# Altium schematic sheet 01.W7500.SchDoc
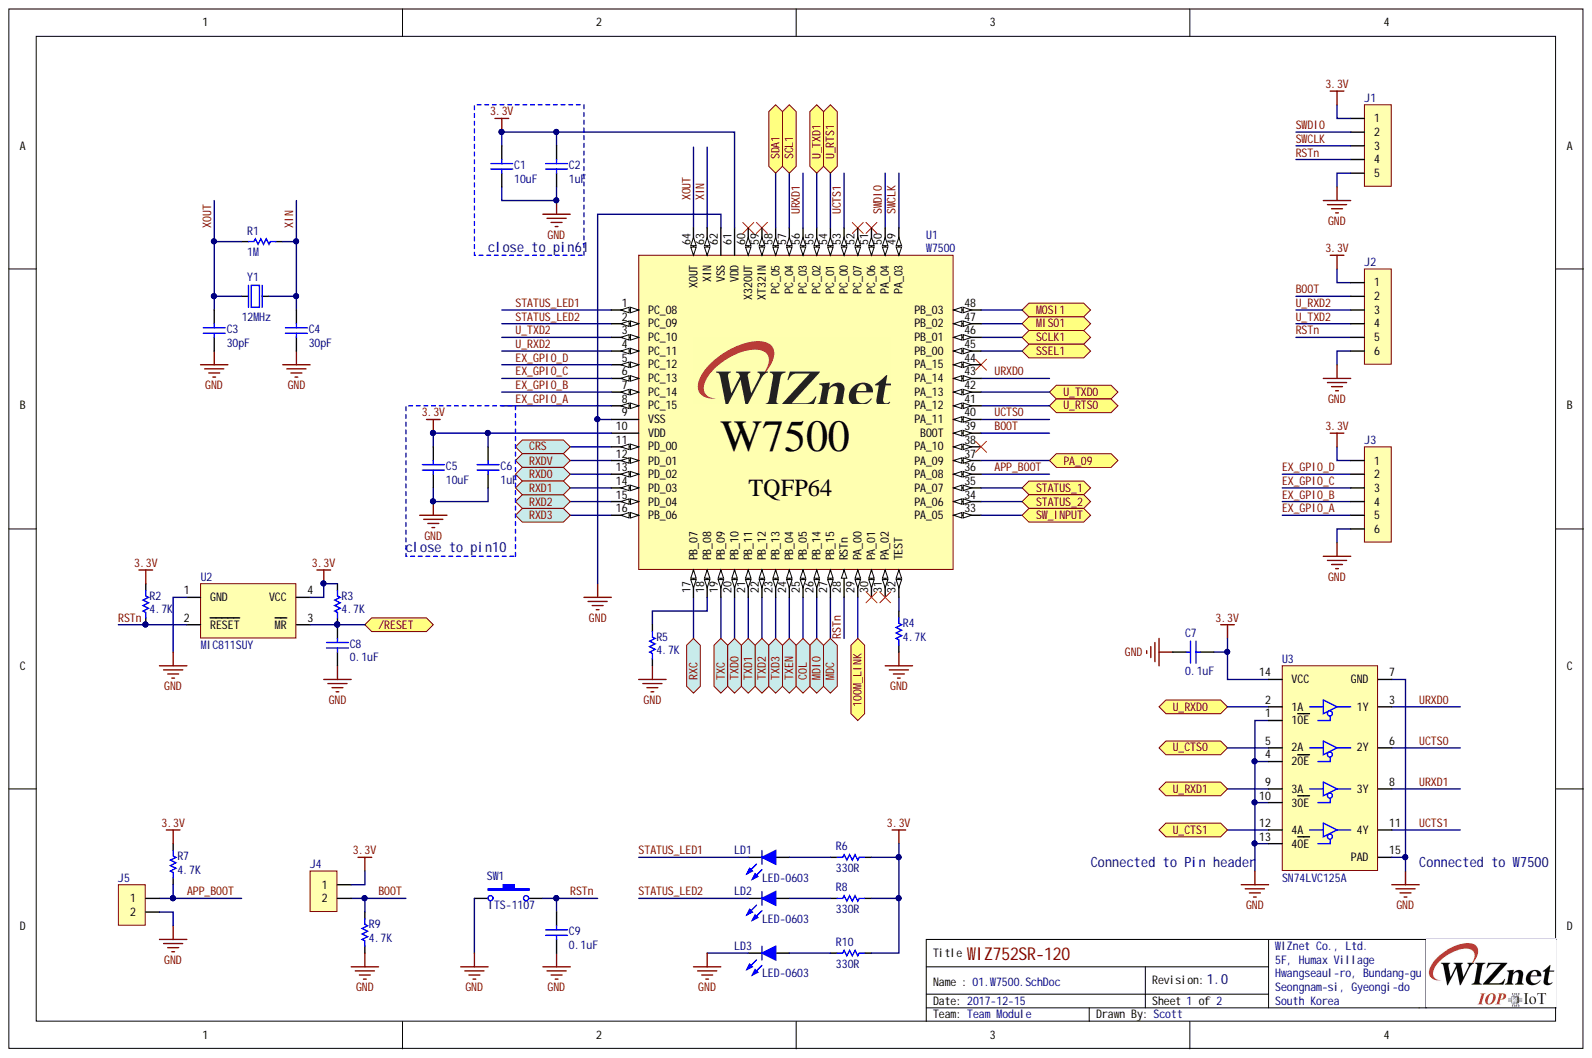
[diagram p0: 01.W7500.SchDoc]

## Parts
C1  10uF  [CAP-1206]
C2  1uF  [CAP-0603]
C3  30pF  [CAP-0603]
C4  30pF  [CAP-0603]
C5  10uF  [CAP-1206]
C6  1uF  [CAP-0603]
C7  0.1uF  [CAP-0603]
C8  0.1uF  [CAP-0603]
C9  0.1uF  [CAP-0603]
J1  1.27mm 1x5 Pin Header DIP  [IDC-1X5(1.27MM)]  (value not drawn)
J2  2.54mm 1x6 Pin Header DIP  [IDC-1X6]  (value not drawn)
J3  2.54mm 1x6 Pin Header DIP  [IDC-1X6]  (value not drawn)
J4  2.54mm 1x2 Pin Header DIP  [IDC-1X2]  (value not drawn)
J5  2.54mm 1x2 Pin Header DIP  [IDC-1X2]  (value not drawn)
LD1  LED-0603  [LED-0603-GREEN]
LD2  LED-0603  [LED-0603-GREEN]
LD3  LED-0603  [LED-0603-RED]
R1  1M  [RES-0603]
R2  4.7K  [RES-0603]
R3  4.7K  [RES-0603]
R4  4.7K  [RES-0603]
R5  4.7K  [RES-0603]
R6  330R  [RES-0603]
R7  4.7K  [RES-0603]
R8  330R  [RES-0603]
R9  4.7K  [RES-0603]
R10  330R  [RES-0603]
SW1  ITS-1107  [IT-1107(SMD)]
U1  W7500  [W7500-LQFP64]
U2  MIC811SUY  [SOT-143]
U3  SN74LVC125A  [VQFN14(0.5/3.65X3.65)]
Y1  12MHz  [OSC-TZ1067B]

## Ports
100M_LINK, /RESET, COL, CRS, MDC, MDIO, MISO1, MOSI1, PA_09, RXC, RXD0, RXD1, RXD2, RXD3, RXDV, SCL1, SCLK1, SDA1, SSEL1, STATUS_1, STATUS_2, SW_INPUT, TXC, TXD0, TXD1, TXD2, TXD3, TXEN, U_CTS0, U_CTS1, U_RTS0, U_RTS1, U_RXD0, U_RXD1, U_TXD0, U_TXD1

## Nets
3.3V: C1.2 C2.2 C5.2 C6.2 C7.2 J1.1 J2.1 J3.1 J4.1 R2.2 R3.2 R6.2 R7.2 R8.2 R10.2 U1.10(VDD) U1.61(VDD) U2.4(VCC) U3.14(VCC)
GND: C1.1 C2.1 C3.1 C4.1 C5.1 C6.1 C7.1 C8.2 C9.2 J1.5 J2.6 J3.6 J5.2 LD3.2 R4.1 R5.1 R9.1 SW1.1 U1.9(VSS) U1.62(VSS) U2.1(GND) U3.1(1OE) U3.4(2OE) U3.7(GND) U3.10(3OE) U3.13(4OE) U3.15(PAD) Y1.2 Y1.4
100M_LINK: U1.29(PA_00) ; port 100M_LINK
/RESET: C8.1 R3.1 U2.3(MR) ; port /RESET
APP_BOOT: J5.1 R7.1 U1.36(PA_08)
BOOT: J2.2 J4.2 R9.2 U1.39(BOOT)
COL: U1.25(PB_05) ; port COL
CRS: U1.11(PD_00) ; port CRS
EX_GPIO_A: J3.5 U1.8(PC_15)
EX_GPIO_B: J3.4 U1.7(PC_14)
EX_GPIO_C: J3.3 U1.6(PC_13)
EX_GPIO_D: J3.2 U1.5(PC_12)
MDC: U1.27(PB_15) ; port MDC
MDIO: U1.26(PB_14) ; port MDIO
MISO1: U1.47(PB_02) ; port MISO1
MOSI1: U1.48(PB_03) ; port MOSI1
PA_09: U1.37(PA_09) ; port PA_09
RSTn: C9.1 J1.4 J2.5 R2.1 SW1.2 U1.28(RSTn) U2.2(RESET)
RXC: U1.17(PB_07) ; port RXC
RXD0: U1.13(PD_02) ; port RXD0
RXD1: U1.14(PD_03) ; port RXD1
RXD2: U1.15(PD_04) ; port RXD2
RXD3: U1.16(PB_06) ; port RXD3
RXDV: U1.12(PD_01) ; port RXDV
SCL1: U1.57(PC_04) ; port SCL1
SCLK1: U1.46(PB_01) ; port SCLK1
SDA1: U1.58(PC_05) ; port SDA1
SSEL1: U1.45(PB_00) ; port SSEL1
STATUS_1: U1.35(PA_07) ; port STATUS_1
STATUS_2: U1.34(PA_06) ; port STATUS_2
STATUS_LED1: LD1.2 U1.1(PC_08)
STATUS_LED2: LD2.2 U1.2(PC_09)
SW_INPUT: U1.33(PA_05) ; port SW_INPUT
SWCLK: J1.3 U1.49(PA_03)
SWDIO: J1.2 U1.50(PA_04)
TXC: U1.19(PB_09) ; port TXC
TXD0: U1.20(PB_10) ; port TXD0
TXD1: U1.21(PB_11) ; port TXD1
TXD2: U1.22(PB_12) ; port TXD2
TXD3: U1.23(PB_13) ; port TXD3
TXEN: U1.24(PB_04) ; port TXEN
U_CTS0: U3.5(2A) ; port U_CTS0
U_CTS1: U3.12(4A) ; port U_CTS1
U_RTS0: U1.41(PA_12) ; port U_RTS0
U_RTS1: U1.54(PC_01) ; port U_RTS1
U_RXD0: U3.2(1A) ; port U_RXD0
U_RXD1: U3.9(3A) ; port U_RXD1
U_RXD2: J2.3 U1.4(PC_11)
U_TXD0: U1.42(PA_13) ; port U_TXD0
U_TXD1: U1.55(PC_02) ; port U_TXD1
U_TXD2: J2.4 U1.3(PC_10)
UCTS0: U1.40(PA_11) U3.6(2Y)
UCTS1: U1.53(PC_00) U3.11(4Y)
URXD0: U1.43(PA_14) U3.3(1Y)
URXD1: U1.56(PC_03) U3.8(3Y)
XIN: C4.2 R1.1 U1.63(XIN) Y1.3
XOUT: C3.2 R1.2 U1.64(XOUT) Y1.1
NetLD1_1: LD1.1 R6.1
NetLD2_1: LD2.1 R8.1
NetLD3_1: LD3.1 R10.1
NetR4_2: R4.2 U1.32(TEST)
NetR5_2: R5.2 U1.18(PB_08)

Not connected: U1 30(PA_01) 31(PA_02) 38(PA_10) 44(PA_15) 51(PC_06) 52(PC_07) 59(XT32IN) 60(X32OUT)

## Library data of the parts
C1 C5 = CAP-1206: Ceramic CAP 1206(3216); Component Kind: Standard; Library Name: Scott_lib.IntLib
C2-C4 C6-C9 = CAP-0603: Ceramic CAP 0603(1608); Component Kind: Standard; Library Name: Scott_lib.IntLib
R1-R10 = RES-0603: RES0603; Component Kind: Standard; Library Name: Scott_lib.IntLib
SW1 = ITS-1107(SMD): TACT Switch (SMD); Component Kind: Standard; Library Name: Scott_lib.IntLib
U1 = W7500: WIZnet ARM Cortex-M0; Component Kind: Standard; Library Name: Scott_lib.IntLib
U2 = MIC811SUY: Microprocessor Reset Circuits; Component Kind: Standard; Library Name: Scott_lib.IntLib
U3 = SN74LVC125A: Quadruple Bus Buffer Gate With 3-State Outputs; Component Kind: Standard; Library Name: Edward.IntLib
Y1 = OSC-TZ1067B-12MHz: X-tal 12MHz,3.2x2.5 SMD, CL=20pF; Component Kind: Standard; Library Name: Scott_lib.IntLib
J1 = 1.27mm 1x5 Pin Header DIP: Component Kind: Standard; Description: 1.27mm 1x5 Pin Header DIP; Library Name: Scott_lib.IntLib
J2 J3 = 2.54mm 1x6 Pin Header DIP: Component Kind: Standard; Description: 2.54mm 1x6 Pin Header DIP; Library Name: Scott_lib.IntLib
J4 J5 = 2.54mm 1x2 Pin Header DIP: Component Kind: Standard; Description: 2.54mm 1x2 Pin Header DIP; Library Name: Scott_lib.IntLib
LD1-LD3 = LED-0603: Component Kind: Standard; Description: LED-0603; Library Name: Scott_lib.IntLib
